# Altium schematic sheet Power.SchDoc
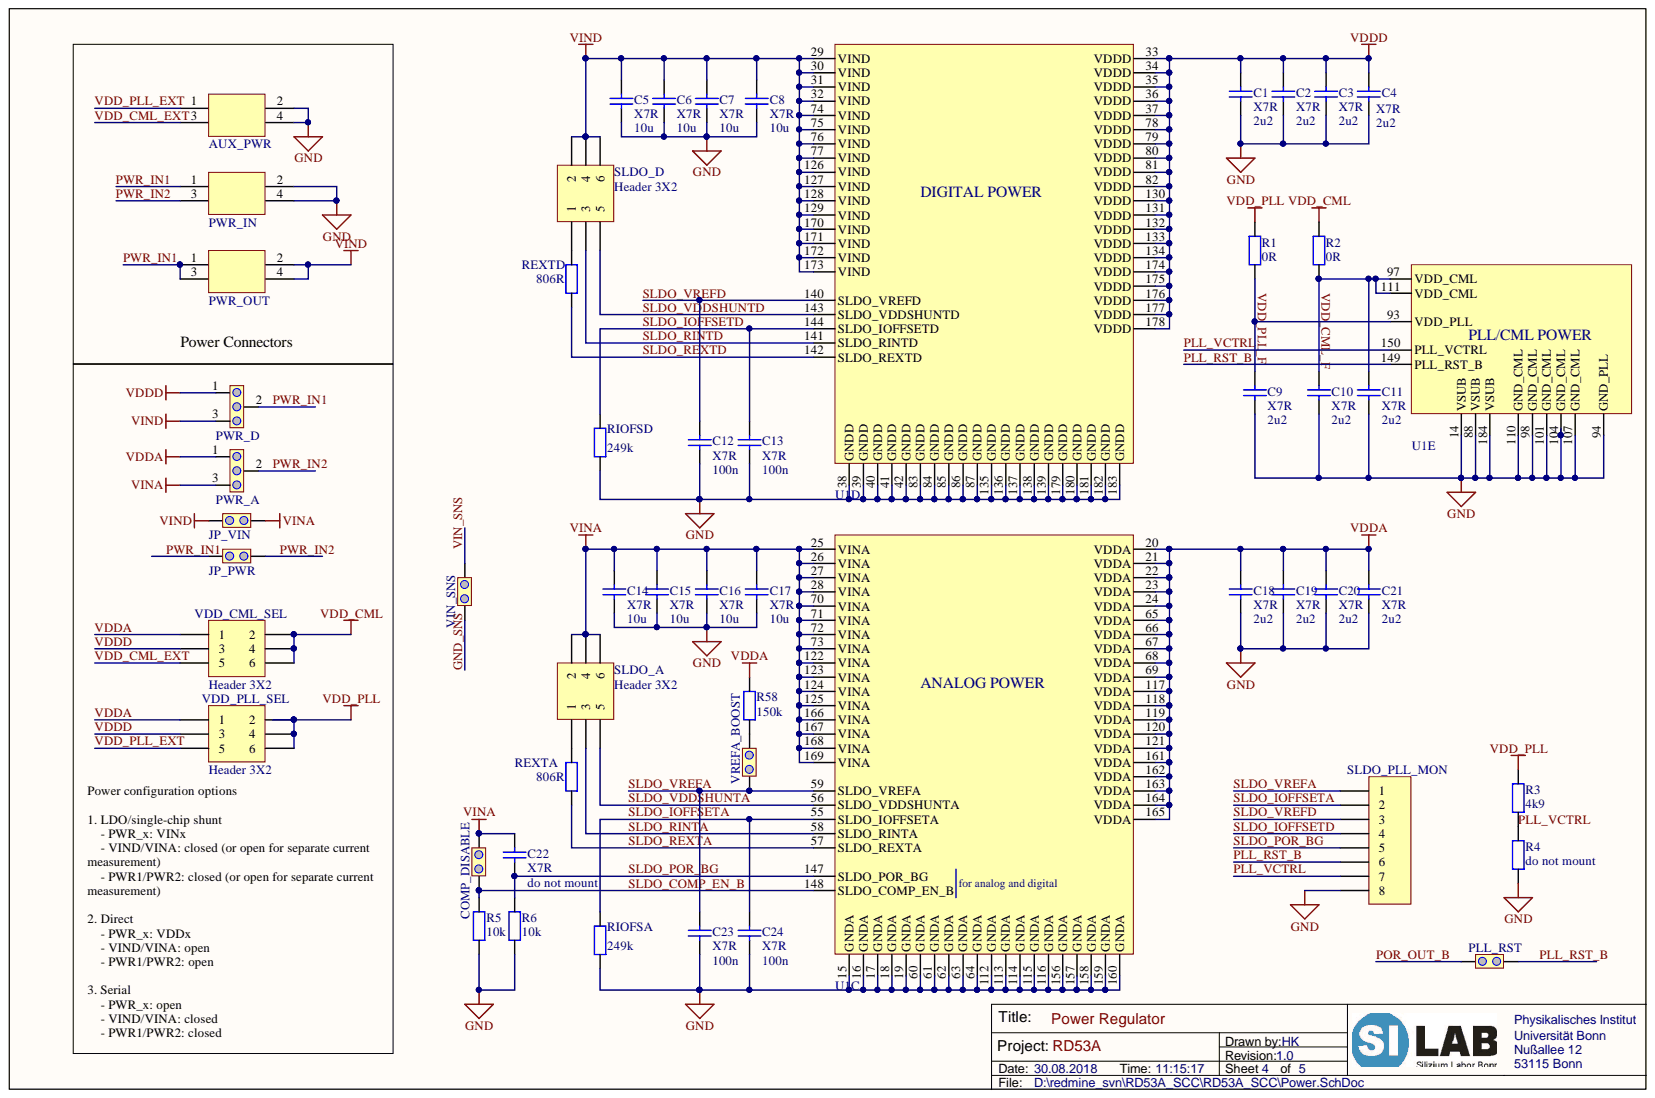
[diagram p0: Power.SchDoc]

## Parts
AUX_PWR  -  [MOLEX SD-5569 -04A2]  (value not drawn)
C1  X7R  value 2u2  [C0402_imp_L]
C2  X7R  value 2u2  [C0402_imp_L]
C3  X7R  value 2u2  [C0402_imp_L]
C4  X7R  value 2u2  [C0402_imp_L]
C5  X7R  value 10u  [C0402_imp_L]
C6  X7R  value 10u  [C0402_imp_L]
C7  X7R  value 10u  [C0402_imp_L]
C8  X7R  value 10u  [C0402_imp_L]
C9  X7R  value 2u2  [C0402_imp_L]
C10  X7R  value 2u2  [C0402_imp_L]
C11  X7R  value 2u2  [C0402_imp_L]
C12  X7R  value 100n  [C0402_imp_L]
C13  X7R  value 100n  [C0402_imp_L]
C14  X7R  value 10u  [C0402_imp_L]
C15  X7R  value 10u  [C0402_imp_L]
C16  X7R  value 10u  [C0402_imp_L]
C17  X7R  value 10u  [C0402_imp_L]
C18  X7R  value 2u2  [C0402_imp_L]
C19  X7R  value 2u2  [C0402_imp_L]
C20  X7R  value 2u2  [C0402_imp_L]
C21  X7R  value 2u2  [C0402_imp_L]
C22  X7R  value do not mount  [C0402_imp_L]
C23  X7R  value 100n  [C0402_imp_L]
C24  X7R  value 100n  [C0402_imp_L]
COMP_DISABLE  -  [CON 1x2 std]  (value not drawn)
JP_PWR  -  [CON 1x2 std]  (value not drawn)
JP_VIN  -  [CON 1x2 std]  (value not drawn)
PLL_RST  -  [CON 1x2 std]  (value not drawn)
PWR_A  -  [HDR 1x3]  (value not drawn)
PWR_D  -  [HDR 1x3]  (value not drawn)
PWR_IN  -  [MOLEX SD-5569 -04A2]  (value not drawn)
PWR_OUT  -  [MOLEX SD-5569 -04A2]  (value not drawn)
R1  R  value 0R  [R0402_imp_N]
R2  R  value 0R  [R0402_imp_N]
R3  R  value 4k9  [R0402_imp_N]
R4  R  value do not mount  [R0402_imp_N]
R5  R  value 10k  [R0402_imp_N]
R6  R  value 10k  [R0402_imp_N]
R58  R  value 150k  [R0402_imp_N]
REXTA  R  value 806R  [R0402_imp_N]
REXTD  R  value 806R  [R0402_imp_N]
RIOFSA  R  value 249k  [R0402_imp_N]
RIOFSD  R  value 249k  [R0402_imp_N]
SLDO_A  Header 3X2  [HDR2X3]
SLDO_D  Header 3X2  [HDR2X3]
SLDO_PLL_MON  (no value)  [HDR1X8]
U1  RD53A  [RD53A Chip]  (value not drawn)  (parts C,D,E)
VDD_CML_SEL  Header 3X2  [HDR2X3]
VDD_PLL_SEL  Header 3X2  [HDR2X3]
VIN_SNS  -  [CON 1x2 std]  (value not drawn)
VREFA_BOOST  -  [CON 1x2 std]  (value not drawn)

## Nets
GND: AUX_PWR.2 AUX_PWR.4 C1.2 C2.2 C3.2 C4.2 C5.2 C6.2 C7.2 C8.2 C9.2 C10.2 C11.2 C12.2 C13.2 C14.2 C15.2 C16.2 C17.2 C18.2 C19.2 C20.2 C21.2 C23.2 C24.2 PWR_IN.2 PWR_IN.4 R4.1 R5.1 R6.1 RIOFSA.1 RIOFSD.1 SLDO_PLL_MON.8 U1.14(VSUB) U1.15(GNDA) U1.16(GNDA) U1.17(GNDA) U1.18(GNDA) U1.19(GNDA) U1.38(GNDD) U1.39(GNDD) U1.40(GNDD) U1.41(GNDD) U1.42(GNDD) U1.60(GNDA) U1.61(GNDA) U1.62(GNDA) U1.63(GNDA) U1.64(GNDA) U1.83(GNDD) U1.84(GNDD) U1.85(GNDD) U1.86(GNDD) U1.87(GNDD) U1.88(VSUB) U1.94(GND_PLL) U1.98(GND_CML) U1.101(GND_CML) U1.104(GND_CML) U1.107(GND_CML) U1.110(GND_CML) U1.112(GNDA) U1.113(GNDA) U1.114(GNDA) U1.115(GNDA) U1.116(GNDA) U1.135(GNDD) U1.136(GNDD) U1.137(GNDD) U1.138(GNDD) U1.139(GNDD) U1.156(GNDA) U1.157(GNDA) U1.158(GNDA) U1.159(GNDA) U1.160(GNDA) U1.179(GNDD) U1.180(GNDD) U1.181(GNDD) U1.182(GNDD) U1.183(GNDD) U1.184(VSUB)
VDD_CML: R2.1 VDD_CML_SEL.2 VDD_CML_SEL.4 VDD_CML_SEL.6
VDD_PLL: R1.1 R3.2 VDD_PLL_SEL.2 VDD_PLL_SEL.4 VDD_PLL_SEL.6
VINA: C14.1 C15.1 C16.1 C17.1 C22.1 COMP_DISABLE.2 JP_VIN.2 PWR_A.3 SLDO_A.2 SLDO_A.4 SLDO_A.6 U1.25(VINA) U1.26(VINA) U1.27(VINA) U1.28(VINA) U1.70(VINA) U1.71(VINA) U1.72(VINA) U1.73(VINA) U1.122(VINA) U1.123(VINA) U1.124(VINA) U1.125(VINA) U1.166(VINA) U1.167(VINA) U1.168(VINA) U1.169(VINA)
VIND: C5.1 C6.1 C7.1 C8.1 JP_VIN.1 PWR_D.3 PWR_OUT.2 PWR_OUT.4 SLDO_D.2 SLDO_D.4 SLDO_D.6 U1.29(VIND) U1.30(VIND) U1.31(VIND) U1.32(VIND) U1.74(VIND) U1.75(VIND) U1.76(VIND) U1.77(VIND) U1.126(VIND) U1.127(VIND) U1.128(VIND) U1.129(VIND) U1.170(VIND) U1.171(VIND) U1.172(VIND) U1.173(VIND)
GND_SNS: VIN_SNS.1
PLL_RST_B: PLL_RST.2 SLDO_PLL_MON.6 U1.149(PLL_RST_B)
PLL_VCTRL: R3.1 R4.2 SLDO_PLL_MON.7 U1.150(PLL_VCTRL)
POR_OUT_B: PLL_RST.1
PWR_IN1: JP_PWR.1 PWR_D.2 PWR_IN.1 PWR_OUT.1 PWR_OUT.3
PWR_IN2: JP_PWR.2 PWR_A.2 PWR_IN.3
SLDO_COMP_EN_B: COMP_DISABLE.1 R5.2 U1.148(SLDO_COMP_EN_B)
SLDO_IOFFSETA: C24.1 RIOFSA.2 SLDO_PLL_MON.2 U1.55(SLDO_IOFFSETA)
SLDO_IOFFSETD: C13.1 RIOFSD.2 SLDO_PLL_MON.4 U1.144(SLDO_IOFFSETD)
SLDO_POR_BG: C22.2 R6.2 SLDO_PLL_MON.5 U1.147(SLDO_POR_BG)
SLDO_REXTA: REXTA.1 U1.57(SLDO_REXTA)
SLDO_REXTD: REXTD.1 U1.142(SLDO_REXTD)
SLDO_RINTA: SLDO_A.3 U1.58(SLDO_RINTA)
SLDO_RINTD: SLDO_D.3 U1.141(SLDO_RINTD)
SLDO_VDDSHUNTA: SLDO_A.5 U1.56(SLDO_VDDSHUNTA)
SLDO_VDDSHUNTD: SLDO_D.5 U1.143(SLDO_VDDSHUNTD)
SLDO_VREFA: C23.1 SLDO_PLL_MON.1 U1.59(SLDO_VREFA) VREFA_BOOST.1
SLDO_VREFD: C12.1 SLDO_PLL_MON.3 U1.140(SLDO_VREFD)
VDD_CML_EXT: AUX_PWR.3 VDD_CML_SEL.5
VDD_CML_F: C10.1 C11.1 R2.2 U1.97(VDD_CML) U1.111(VDD_CML)
VDD_PLL_EXT: AUX_PWR.1 VDD_PLL_SEL.5
VDD_PLL_F: C9.1 R1.2 U1.93(VDD_PLL)
VDDA: C18.1 C19.1 C20.1 C21.1 PWR_A.1 R58.2 U1.20(VDDA) U1.21(VDDA) U1.22(VDDA) U1.23(VDDA) U1.24(VDDA) U1.65(VDDA) U1.66(VDDA) U1.67(VDDA) U1.68(VDDA) U1.69(VDDA) U1.117(VDDA) U1.118(VDDA) U1.119(VDDA) U1.120(VDDA) U1.121(VDDA) U1.161(VDDA) U1.162(VDDA) U1.163(VDDA) U1.164(VDDA) U1.165(VDDA) VDD_CML_SEL.1 VDD_PLL_SEL.1
VDDD: C1.1 C2.1 C3.1 C4.1 PWR_D.1 U1.33(VDDD) U1.34(VDDD) U1.35(VDDD) U1.36(VDDD) U1.37(VDDD) U1.78(VDDD) U1.79(VDDD) U1.80(VDDD) U1.81(VDDD) U1.82(VDDD) U1.130(VDDD) U1.131(VDDD) U1.132(VDDD) U1.133(VDDD) U1.134(VDDD) U1.174(VDDD) U1.175(VDDD) U1.176(VDDD) U1.177(VDDD) U1.178(VDDD) VDD_CML_SEL.3 VDD_PLL_SEL.3
VIN_SNS: VIN_SNS.2
NetR58_1: R58.1 VREFA_BOOST.2
NetREXTA_2: REXTA.2 SLDO_A.1
NetREXTD_2: REXTD.2 SLDO_D.1

## Library data of the parts
AUX_PWR PWR_IN PWR_OUT = MOLEX SD-5569-04: MOLEX 4 pin Power Connector, SD-5569-0a; Class: Connector; Comment_1: Molex; Component Kind: Standard; Constraints: -; HelpURL: -; LatestRevisionDate: 24-Sep-2008; PackageDescription: -; Type_1: SD-5569; Value: -; Library Name: Silab_Lib.IntLib; Publisher: Silab C.H.
C1-C21 C23 C24 = C: Capacitor; Class: Passive; Constraints: 6.3V; Type_1: SMD Chip Capacitor; Comment_1: -; Component Kind: Standard; HelpURL: -; LatestRevisionDate: 27-Nov-2007; PackageDescription: -; Signal Integrity: Capacitor; Simulation: Capacitor; Library Name: Silab_Lib.IntLib; Publisher: Silab J.S.
C22 = C: Capacitor; Class: Passive; Constraints: 6.3V; Type_1: SMD Chip Capacitor; Value: do not mount; Comment_1: -; Component Kind: Standard; HelpURL: -; LatestRevisionDate: 27-Nov-2007; PackageDescription: -; Signal Integrity: Capacitor; Simulation: Capacitor; Library Name: Silab_Lib.IntLib; Publisher: Silab J.S.
COMP_DISABLE JP_PWR JP_VIN PLL_RST VIN_SNS VREFA_BOOST = JUMPER 1X2S: Jumper, Solderbridge 1x2; Class: Connector; Comment_1: -; Component Kind: Standard; Constraints: -; HelpURL: -; LatestRevisionDate: 24-Sep-2008; PackageDescription: -; Type_1: -; Value: -; Library Name: Silab_Lib.IntLib; Publisher: Silab C.H.
PWR_A PWR_D = JUMPER 1X3S: Jumper, Solderbridge 1x3; Class: Connector; Comment_1: -; Component Kind: Standard; Constraints: -; HelpURL: -; LatestRevisionDate: 24-Sep-2008; PackageDescription: -; Type_1: -; Value: -; Library Name: Silab_Lib.IntLib; Publisher: Silab C.H.
R1-R6 R58 REXTA REXTD RIOFSA RIOFSD = R: SMD Chip Resistor; Class: Passive; Constraints: 1%; Comment_1: -; Component Kind: Standard; HelpURL: -; LatestRevisionDate: 27-Nov-2007; PackageDescription: -; Signal Integrity: Resistor; Simulation: Resistor; Type_1: SMD Chip Resistor; Library Name: Silab_Lib.IntLib; Publisher: Silab J.S.
SLDO_A SLDO_D VDD_CML_SEL VDD_PLL_SEL = Header 3X2: Header, 3-Pin, Dual row; Component Kind: Standard; LatestRevisionDate: 17-Jul-2002; LatestRevisionNote: Re-released for DXP Platform.; Library Name: Miscellaneous Connectors.IntLib; Signal Integrity: Connector; Publisher: Altium Limited
SLDO_PLL_MON = Header 8: Header, 8-Pin; Component Kind: Standard; LatestRevisionDate: 17-Jul-2002; LatestRevisionNote: Re-released for DXP Platform.; Library Name: Miscellaneous Connectors.IntLib; Signal Integrity: Connector; Publisher: Altium Limited
U1 = RD53A: Component Kind: Standard; Description: RD53A; Library Name: RD53A.SchLib
